# Altium schematic sheet Sheet1.SchDoc
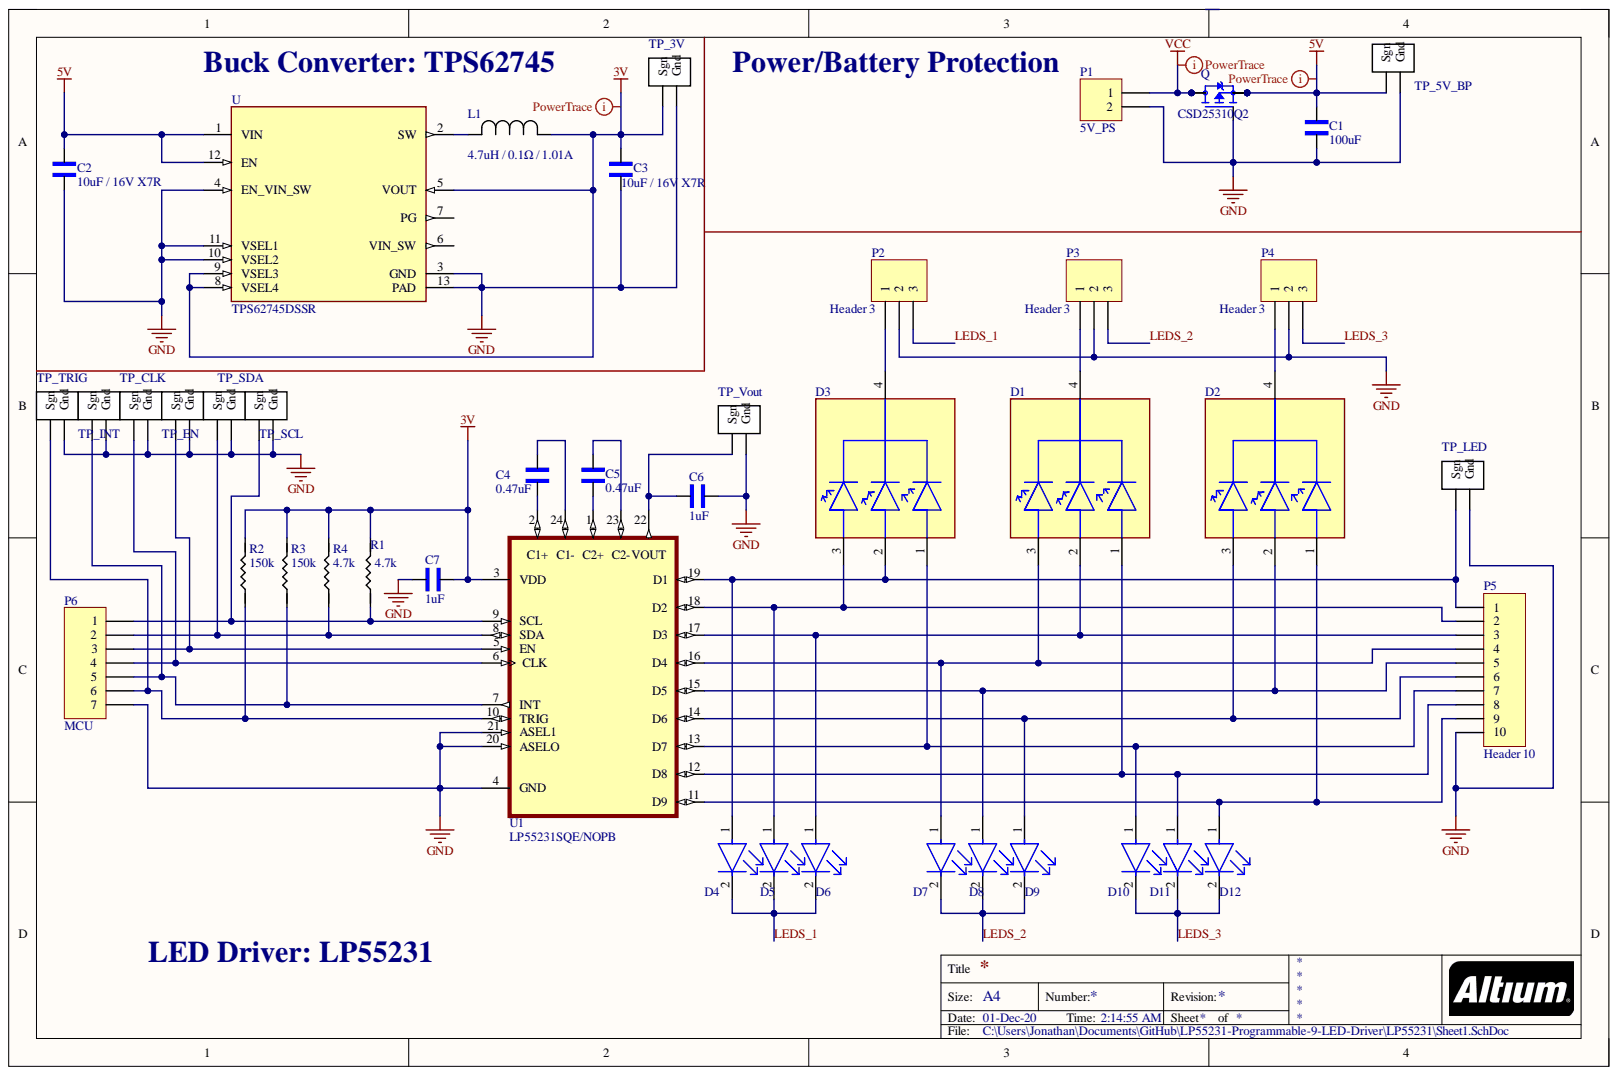
[diagram p0: Sheet1.SchDoc]

## Parts
C1  100uF  [1206_Passive]
C2  10uF  value 10uF / 16V X7R  [1206_Passive]
C3  10uF  value 10uF / 16V X7R  [1206_Passive]
C4  0.47uF  [1206_Passive]
C5  0.47uF  [1206_Passive]
C6  1uF  [1206_Passive]
C7  1uF  [1206_Passive]
D1  XZM2CRKM2DGFBB45SCCB  [SunLED_XZM2CRKM2DGFBB45SCCB_0]  (value not drawn)
D2  XZM2CRKM2DGFBB45SCCB  [SunLED_XZM2CRKM2DGFBB45SCCB_0]  (value not drawn)
D3  XZM2CRKM2DGFBB45SCCB  [SunLED_XZM2CRKM2DGFBB45SCCB_0]  (value not drawn)
D4  CLM3C-WKW-CWBYA453  [Cree_CLM3C-WKW_MFG]  (value not drawn)
D5  CLM3C-WKW-CWBYA453  [Cree_CLM3C-WKW_MFG]  (value not drawn)
D6  CLM3C-WKW-CWBYA453  [Cree_CLM3C-WKW_MFG]  (value not drawn)
D7  CLM3C-WKW-CWBYA453  [Cree_CLM3C-WKW_MFG]  (value not drawn)
D8  CLM3C-WKW-CWBYA453  [Cree_CLM3C-WKW_MFG]  (value not drawn)
D9  CLM3C-WKW-CWBYA453  [Cree_CLM3C-WKW_MFG]  (value not drawn)
D10  CLM3C-WKW-CWBYA453  [Cree_CLM3C-WKW_MFG]  (value not drawn)
D11  CLM3C-WKW-CWBYA453  [Cree_CLM3C-WKW_MFG]  (value not drawn)
D12  CLM3C-WKW-CWBYA453  [Cree_CLM3C-WKW_MFG]  (value not drawn)
L1  4.7uH / 0.1Ω / 1.01A  [IND_IHLP-3232DZ]
P1  5V_PS  [HDR1X2]
P2  Header 3  [HDR1X3]
P3  Header 3  [HDR1X3]
P4  Header 3  [HDR1X3]
P5  Header 10  [HDR1X10]
P6  MCU  [HDR1X7]
Q  CSD25310Q2  [TRANS_CSD25310Q2]
R1  value 4.7k  [1206_Passive]  (hidden comment: 100m)
R2  value 150k  [1206_Passive]  (hidden comment: 100m)
R3  value 150k  [1206_Passive]  (hidden comment: 100m)
R4  value 4.7k  [1206_Passive]  (hidden comment: 100m)
TP_3V  10x Probe TP  [TP10x_Probe]  (value not drawn)
TP_5V_BP  10x Probe TP  [TP10x_Probe]  (value not drawn)
TP_CLK  10x Probe TP  [TP10x_Probe]  (value not drawn)
TP_EN  10x Probe TP  [TP10x_Probe]  (value not drawn)
TP_INT  10x Probe TP  [TP10x_Probe]  (value not drawn)
TP_LED  10x Probe TP  [TP10x_Probe]  (value not drawn)
TP_SCL  10x Probe TP  [TP10x_Probe]  (value not drawn)
TP_SDA  10x Probe TP  [TP10x_Probe]  (value not drawn)
TP_TRIG  10x Probe TP  [TP10x_Probe]  (value not drawn)
TP_Vout  10x Probe TP  [TP10x_Probe]  (value not drawn)
U  TPS62745DSSR  [DSS0012A]
U1  LP55231SQE/NOPB  [QFN50P400X400X80-25N]

## Nets
3V: C3.2 C7.1 L1.1 R1.2 R2.2 R3.2 R4.2 TP_3V.1 U.5(VOUT) U.8(VSEL4) U.9(VSEL3) U1.3(VDD)
5V: C1.2 C2.2 Q.1 Q.2 Q.5 Q.6 Q.7 TP_5V_BP.1 U.1(VIN) U.12(EN)
GND: C1.1 C2.1 C3.1 C6.2 C7.2 P1.2 P2.2 P3.2 P4.2 P5.10 P6.7 Q.3 TP_3V.2 TP_5V_BP.2 TP_CLK.2 TP_EN.2 TP_INT.2 TP_LED.2 TP_SCL.2 TP_SDA.2 TP_TRIG.2 TP_Vout.2 U.3(GND) U.4(EN_VIN_SW) U.10(VSEL2) U.11(VSEL1) U.13(PAD) U1.4(GND) U1.20(ASELO) U1.21(ASEL1)
VCC: P1.1 Q.4 Q.8
LEDS_1: D4.2 D5.2 D6.2 P2.3
LEDS_2: D7.2 D8.2 D9.2 P3.3
LEDS_3: D10.2 D11.2 D12.2 P4.3
NetC4_1: C4.1 U1.2
NetC4_2: C4.2 U1.24
NetC5_1: C5.1 U1.1
NetC5_2: C5.2 U1.23
NetC6_1: C6.1 TP_Vout.1 U1.22
NetD1_1: D1.1 D11.1 P5.8 U1.12(D8)
NetD1_2: D1.2 D6.1 P5.3 U1.17(D3)
NetD1_3: D1.3 D7.1 P5.4 U1.16(D4)
NetD1_4: D1.4 P3.1
NetD2_1: D2.1 D12.1 P5.9 U1.11(D9)
NetD2_2: D2.2 D8.1 P5.5 U1.15(D5)
NetD2_3: D2.3 D9.1 P5.6 U1.14(D6)
NetD2_4: D2.4 P4.1
NetD3_1: D3.1 D10.1 P5.7 U1.13(D7)
NetD3_2: D3.2 D4.1 P5.1 TP_LED.1 U1.19(D1)
NetD3_3: D3.3 D5.1 P5.2 U1.18(D2)
NetD3_4: D3.4 P2.1
NetL1_2: L1.2 U.2(SW)
NetP6_1: P6.1 R1.1 TP_SCL.1 U1.9(SCL)
NetP6_2: P6.2 R4.1 TP_SDA.1 U1.8(SDA)
NetP6_3: P6.3 TP_EN.1 U1.5(EN)
NetP6_4: P6.4 TP_CLK.1 U1.6(CLK)
NetP6_5: P6.5 R3.1 TP_INT.1 U1.7(INT)
NetP6_6: P6.6 R2.1 TP_TRIG.1 U1.10(TRIG)

Not connected: U 6(VIN_SW) 7(PG)

## Library data of the parts
C1 = C_1uF_1206: 100µF X5R 1206 (3216 Metric); MFR Part #: CL31B105KBHNNNE; Type: Basic; Component Kind: Standard; Digikey Link: https://www.digikey.com/product-detail/en/C1206C105M4RACTU/399-8150-1-ND/3471873/?itemSeq=304982366; Digikey Part: 399-8150-1-ND; LCSC Part#: C1848; Library Name: ECEN5730Lib_2020_09_AR.IntLib; Value: 100uF
C2 = C_1uF_1206: 10µF ±20% 16V Ceramic Capacitor X7R 1206 (3216 Metric); MFR Part #: CL31B105KBHNNNE; Type: Basic; Value: 10uF / 16V X7R; Component Kind: Standard; Digikey Link: https://www.digikey.com/product-detail/en/C1206C105M4RACTU/399-8150-1-ND/3471873/?itemSeq=304982366; Digikey Part: 399-8150-1-ND; LCSC Part#: C1848; Library Name: ECEN5730Lib_2020_09_AR.IntLib
C3 = C_1uF_1206: 10µF ±20% 16V Ceramic Capacitor X7R 1206 (3216 Metric); MFR Part #: CL31B105KBHNNNE; Type: Basic; Component Kind: Standard; Digikey Link: https://www.digikey.com/product-detail/en/C1206C105M4RACTU/399-8150-1-ND/3471873/?itemSeq=304982366; Digikey Part: 399-8150-1-ND; LCSC Part#: C1848; Library Name: ECEN5730Lib_2020_09_AR.IntLib
C4 C5 = C_1uF_1206: 0.47µF ±20% 16V Ceramic Capacitor X7R 1206 (3216 Metric); MFR Part #: CL31B105KBHNNNE; Type: Basic; Component Kind: Standard; Digikey Link: https://www.digikey.com/product-detail/en/C1206C105M4RACTU/399-8150-1-ND/3471873/?itemSeq=304982366; Digikey Part: 399-8150-1-ND; LCSC Part#: C1848; Library Name: ECEN5730Lib_2020_09_AR.IntLib; Value: 0.47uF
C6 C7 = C_1uF_1206: 1µF ±20% 16V Ceramic Capacitor X7R 1206 (3216 Metric); MFR Part #: CL31B105KBHNNNE; Type: Basic; Component Kind: Standard; Digikey Link: https://www.digikey.com/product-detail/en/C1206C105M4RACTU/399-8150-1-ND/3471873/?itemSeq=304982366; Digikey Part: 399-8150-1-ND; LCSC Part#: C1848; Library Name: ECEN5730Lib_2020_09_AR.IntLib; Value: 1uF
L1 = L_5.6uH: 4.7uH  0.1Ω  1.01A; Manufacturer_Part_Number: IHLP3232DZER5R6M11; Built_By: EMA_UL_Team; SourceLibrary: Vishay_2010-01-01_IND_IHLP; Type: DEV; Vendor: VISHAY; Component Kind: Standard; Library Name: ECEN5730Lib_2020_09_AR.IntLib; RefDes: RefDes; Copyright: Copyright (C) 2018 Accelerated Designs. All rights reserved
P1 = Header 2: Header, 2-Pin; Component Kind: Standard; LatestRevisionDate: 17-Jul-2002; LatestRevisionNote: Re-released for DXP Platform.; Library Name: Miscellaneous Connectors.IntLib; Signal Integrity: Connector; Publisher: Altium Limited
P2-P4 = Header 3: Header, 3-Pin; Component Kind: Standard; LatestRevisionDate: 17-Jul-2002; LatestRevisionNote: Re-released for DXP Platform.; Library Name: Miscellaneous Connectors.IntLib; Signal Integrity: Connector; Publisher: Altium Limited
P5 = Header 10: Header, 10-Pin; Component Kind: Standard; LatestRevisionDate: 17-Jul-2002; LatestRevisionNote: Re-released for DXP Platform.; Library Name: Miscellaneous Connectors.IntLib; Signal Integrity: Connector; Publisher: Altium Limited
P6 = Header 7: Header, 7-Pin; Component Kind: Standard; LatestRevisionDate: 17-Jul-2002; LatestRevisionNote: Re-released for DXP Platform.; Library Name: Miscellaneous Connectors.IntLib; Signal Integrity: Connector; Publisher: Altium Limited
Q = CSD25310Q2: P-Channel 20V 20A _Ta_ 2.9W _Ta_ Surface Mount 6-WSON _2x2_; MF: Texas Instruments; Availability: Unavailable; Component Kind: Standard; Library Name: Schlib1.SchLib; MP: CSD25310Q2; Package: WDFN-6 Texas Instruments; Price: None; SnapEDA_Link: https://www.snapeda.com/parts/CSD25310Q2/Texas%20Instruments/view-part/479796/?ref=snap
R1-R4 = R_100m_1206: 100 mOhms ±1% 0.5W, 1/2W Chip Resistor 1206 (3216 Metric) Anti-Sulfur, Moisture Resistant Thin Film; Component Kind: Standard; Digikey Link: https://www.digikey.com/product-detail/en/RNCP1206FTD1K00/RNCP1206FTD1K00CT-ND/2240675/?itemSeq=304982373; Digikey Part: RNCP1206FTD1K00CT-ND; Library Name: ECEN5730Lib_2020_09_AR.IntLib
TP_3V TP_5V_BP TP_CLK TP_EN TP_INT TP_LED TP_SCL TP_SDA TP_TRIG TP_Vout = TP_10x_Probe: Test Point 300 mil centers; Component Kind: Standard; Library Name: ECEN5730Lib_2020_09_AR.IntLib
U = TPS62745DSSR: 3.3V-10V input, 300mA Ultra Low Iq step-down converter with VSEL and input voltage switch 12-WSON -40 to 125; MF: Texas Instruments; Availability: Unavailable; Component Kind: Standard; Library Name: Schlib1.SchLib; MP: TPS62745DSSR; Package: WSON-12 Texas Instruments; Price: None; SnapEDA_Link: https://www.snapeda.com/parts/TPS62745DSSR/Texas%20Instruments/view-part/229862/?ref=snap; Texas_Instruments-Purchase-URL: https://snapeda.com/shop?store=Texas+Instruments&id=229862
U1 = LP55231SQE/NOPB: 9 channel RGB / White LED driver with internal program memory and integrated charge Pump - WQFN 24-WQFN -30 to 85; MF: Texas Instruments; Availability: Warning; Component Kind: Standard; Library Name: Schlib1.SchLib; MP: LP55231SQE/NOPB; Package: WQFN-24 Texas Instruments; Price: None; Purchase-URL: https://pricing.snapeda.com/search/part/LP55231SQE/NOPB/?ref=eda; SnapEDA_Link: https://www.snapeda.com/parts/LP55231SQE/NOPB/Texas%20Instruments/view-part/474412/?ref=snap
D1-D3 = XZM2CRKM2DGFBB45SCCB: Component Kind: Standard; Library Name: Schlib1.SchLib
D4-D12 = CLM3C-WKW-CWBYA453: Component Kind: Standard; Library Name: Schlib1.SchLib
